# Altium schematic sheet K210_音频模块.SchDoc(K210_音频模块)
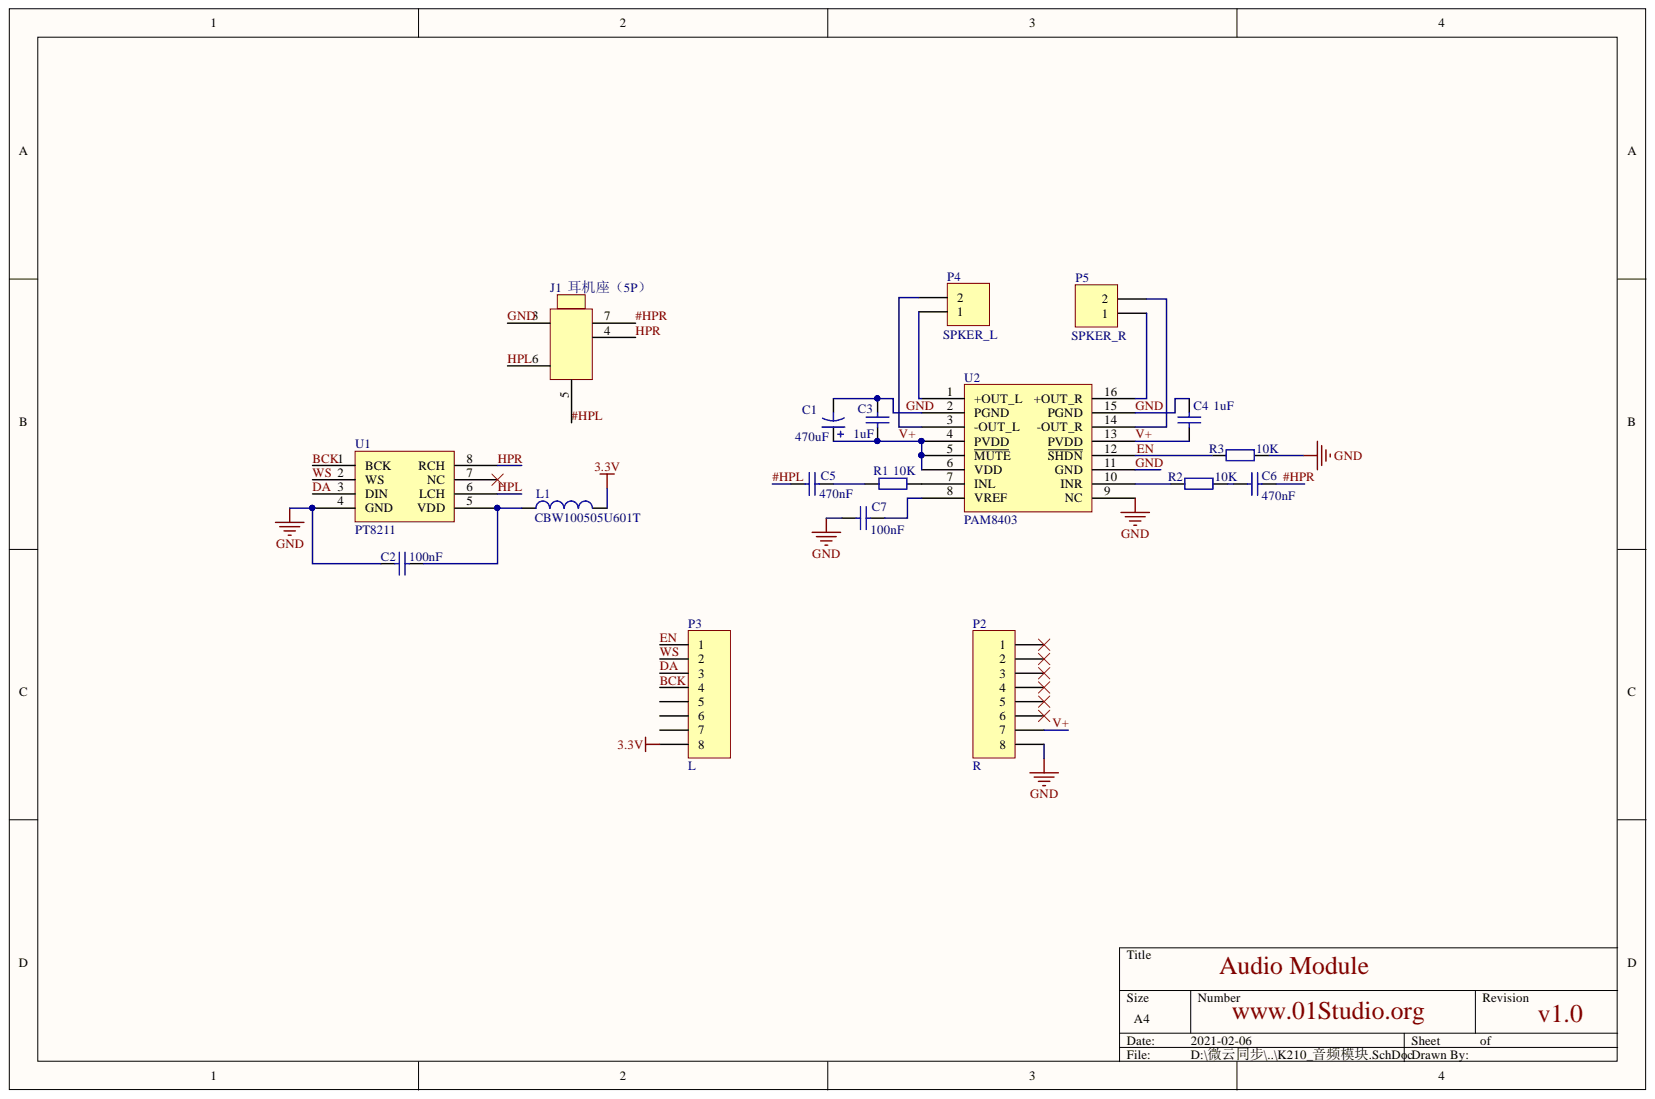
[diagram p0: K210_音频模块.SchDoc]

## Parts
C1  value 470uF  [贴片电容(470uF/6.3*7.7mm)]
C2  value 100nF  [C0603]
C3  value 1uF  [C0603]
C4  value 1uF  [C0603]
C5  value 470nF  [C0603]
C6  value 470nF  [C0603]
C7  value 100nF  [C0603]
J1  耳机座（5P）  [耳机座（PJ-328/5P）]
L1  CBW100505U601T  [L0603]
P2  R  [HDR1X8]
P3  L  [HDR1X8]
P4  SPKER_L  [HDR1X2]
P5  SPKER_R  [HDR1X2]
R1  value 10K  [R0603]
R2  value 10K  [R0603]
R3  value 10K  [R0603]
U1  PT8211  [SOP-8]
U2  PAM8403  [SOP-16]

## Nets
3.3V: L1.2 P3.8
#HPL: C5.2 J1.5
#HPR: C6.1 J1.7
BCK: P3.4 U1.1(BCK)
DA: P3.3 U1.3(DIN)
EN: P3.1 R3.1 U2.12(SHDN)
GND: C1.2 C2.2 C3.1 C4.1 C7.2 J1.3 P2.8 R3.2 U1.4(GND) U2.2(PGND) U2.9(NC) U2.11(GND) U2.15(PGND)
HPL: J1.6 U1.6(LCH)
HPR: J1.4 U1.8(RCH)
V+: C1.1 C3.2 C4.2 P2.7 U2.4(PVDD) U2.5(MUTE) U2.6(VDD) U2.13(PVDD)
WS: P3.2 U1.2(WS)
NetC2_1: C2.1 L1.1 U1.5(VDD)
NetC5_1: C5.1 R1.1
NetC6_2: C6.2 R2.2
NetC7_1: C7.1 U2.8(VREF)
NetP4_1: P4.1 U2.1(+OUT_L)
NetP4_2: P4.2 U2.3(-OUT_L)
NetP5_1: P5.1 U2.16(+OUT_R)
NetP5_2: P5.2 U2.14(-OUT_R)
NetR1_2: R1.2 U2.7(INL)
NetR2_1: R2.1 U2.10(INR)

Not connected: P2 1 2 3 4 5 6; P3 5 6 7; U1 7(NC)

## Library data of the parts
C1 = Cap Pol1: Polarized Capacitor (Radial); Simulation: CAP; Signal Integrity: Cap Pol; Published: 8-Jun-2000; PackageReference: RB7.6-15; Library Name: Miscellaneous Devices.IntLib; LatestRevisionNote: Re-released for DXP Platform.; LatestRevisionDate: 17-Jul-2002; Component Kind: Standard; Publisher: Altium Limited
C2-C7 = Cap: Capacitor; Simulation: CAP; Signal Integrity: Cap; Published: 8-Jun-2000; PackageReference: RAD-0.3; Library Name: Miscellaneous Devices.IntLib; LatestRevisionNote: Re-released for DXP Platform.; LatestRevisionDate: 17-Jul-2002; Component Kind: Standard; Publisher: Altium Limited
L1 = Inductor: Code_IEC: 1005; Simulation: INDUCTOR; Signal Integrity: Inductor; Published: 8-Jun-2000; PackageReference: 0402-A; Library Name: Miscellaneous Devices.IntLib; LatestRevisionNote: Re-released for DXP Platform.; LatestRevisionDate: 17-Jul-2002; Description: Inductor; Component Kind: Standard; Code_JEITA: 0402; Publisher: Altium Limited
P2 P3 = Header 8: Header, 8-Pin; Signal Integrity: Connector; Library Name: Miscellaneous Connectors.IntLib; LatestRevisionNote: Re-released for DXP Platform.; LatestRevisionDate: 17-Jul-2002; Component Kind: Standard; Publisher: Altium Limited
P4 P5 = Header 2: Header, 2-Pin; Signal Integrity: Connector; Library Name: Miscellaneous Connectors.IntLib; LatestRevisionNote: Re-released for DXP Platform.; LatestRevisionDate: 17-Jul-2002; Component Kind: Standard; Publisher: Altium Limited
R1-R3 = Res2: Resistor; Simulation: RESISTOR; Signal Integrity: Res; Published: 8-Jun-2000; PackageReference: AXIAL-0.4; Library Name: Miscellaneous Devices.IntLib; LatestRevisionNote: Re-released for DXP Platform.; LatestRevisionDate: 17-Jul-2002; Component Kind: Standard; Publisher: Altium Limited
J1 = 耳机座（5P）: Component Kind: Standard
U1 = PT8211: Component Kind: Standard
U2 = PAM8403: Component Kind: Standard
